# Altium schematic sheet 设置按键.SchDoc
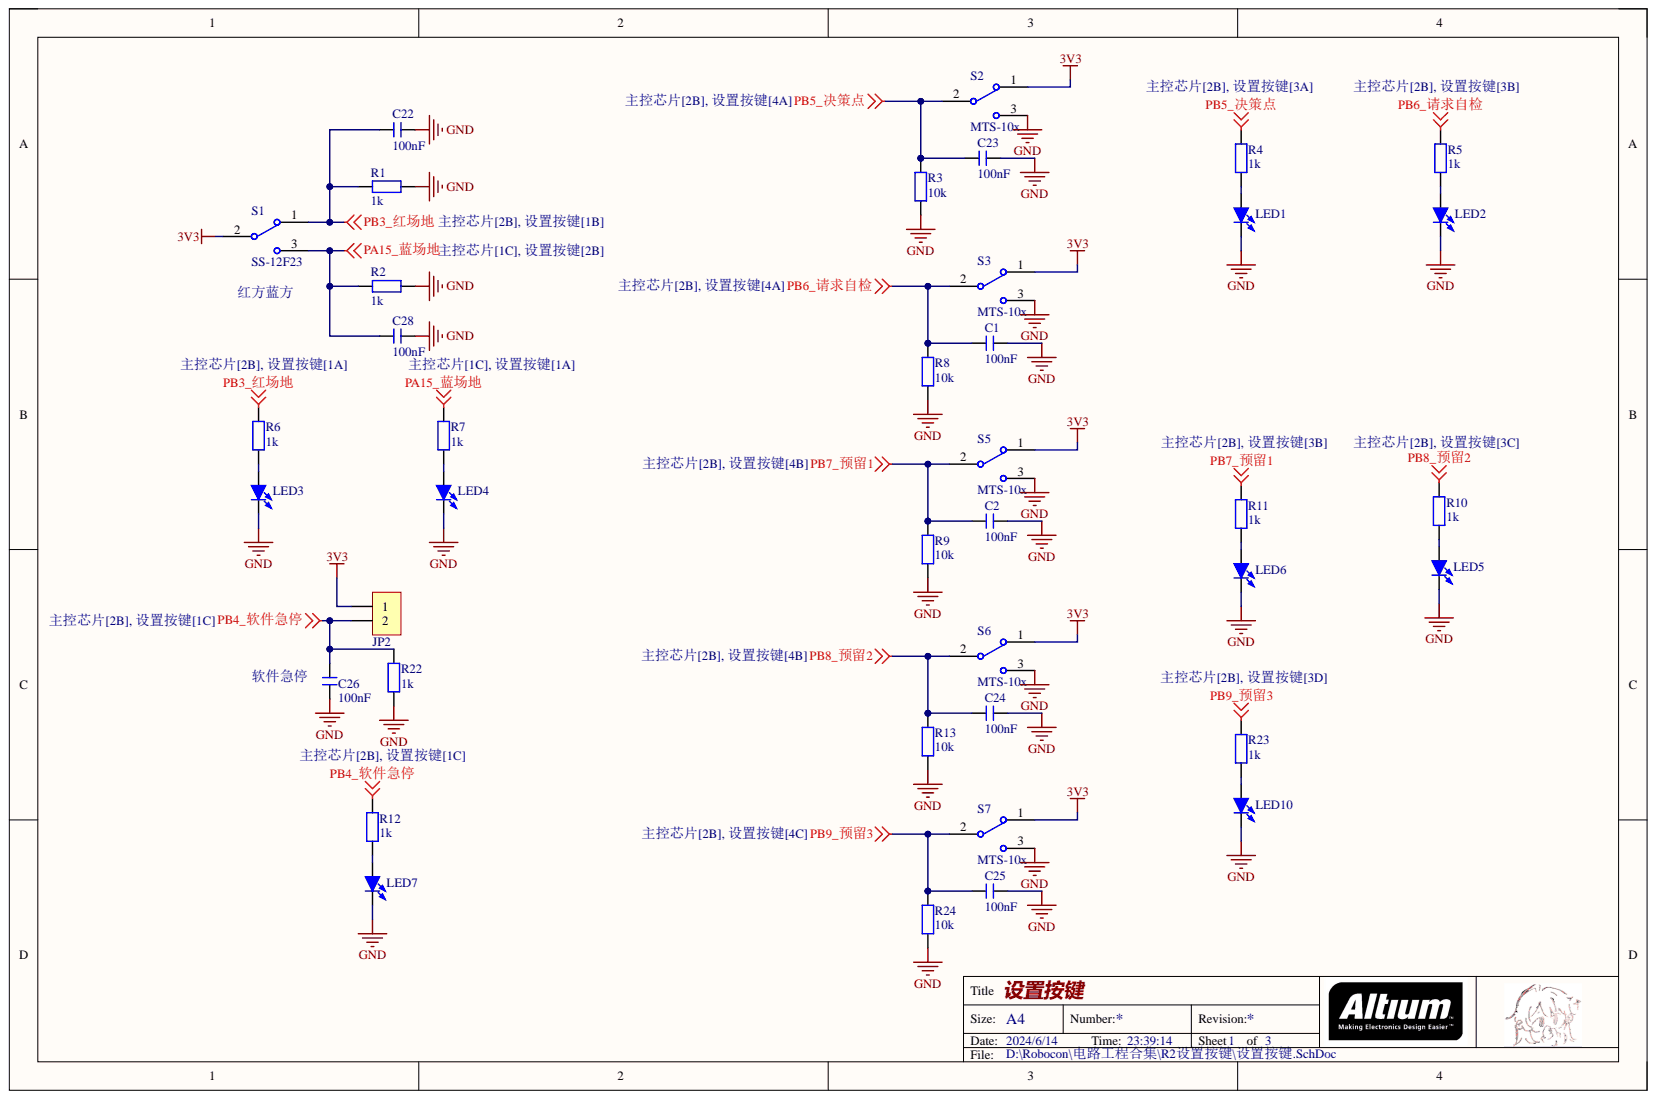
[diagram p0: 设置按键.SchDoc]

## Parts
C1  100nF  [C 0603_L]
C2  100nF  [C 0603_L]
C22  100nF  [C 0603_L]
C23  100nF  [C 0603_L]
C24  100nF  [C 0603_L]
C25  100nF  [C 0603_L]
C26  100nF  [C 0603_L]
C28  100nF  [C 0603_L]
JP2  HDR-1X2  [15EDGRM-02P-3.81mm]  (value not drawn)
LED1  红色  [LED 0603W]  (value not drawn)
LED2  红色  [LED 0603W]  (value not drawn)
LED3  红色  [LED 0603R]  (value not drawn)
LED4  红色  [LED 0603B]  (value not drawn)
LED5  红色  [LED 0603W]  (value not drawn)
LED6  红色  [LED 0603W]  (value not drawn)
LED7  红色  [LED 0603W]  (value not drawn)
LED10  红色  [LED 0603W]  (value not drawn)
R1  1k  [R 0603_L]
R2  1k  [R 0603_L]
R3  10k  [R 0603_L]
R4  1k  [R 0603_L]
R5  1k  [R 0603_L]
R6  1k  [R 0603_L]
R7  1k  [R 0603_L]
R8  10k  [R 0603_L]
R9  10k  [R 0603_L]
R10  1k  [R 0603_L]
R11  1k  [R 0603_L]
R12  1k  [R 0603_L]
R13  10k  [R 0603_L]
R22  1k  [R 0603_L]
R23  1k  [R 0603_L]
R24  10k  [R 0603_L]
S1  SS-12F23  [SS-12F23]
S2  MTS-10x  [MTS-10x]
S3  MTS-10x  [MTS-10x]
S5  MTS-10x  [MTS-10x]
S6  MTS-10x  [MTS-10x]
S7  MTS-10x  [MTS-10x]

## Nets
3V3: JP2.1 S1.2 S2.1 S3.1 S5.1 S6.1 S7.1
GND: C1.1 C2.1 C22.1 C23.1 C24.1 C25.1 C26.2 C28.1 LED1.2 LED2.2 LED3.2 LED4.2 LED5.2 LED6.2 LED7.2 LED10.2 R1.1 R2.1 R3.1 R8.1 R9.1 R13.1 R22.1 R24.1 S2.3 S3.3 S5.3 S6.3 S7.3
PA15_蓝场地: C28.2 R2.2 R7.2 S1.3
PB3_红场地: C22.2 R1.2 R6.2 S1.1
PB4_软件急停: C26.1 JP2.2 R12.2 R22.2
PB5_决策点: C23.2 R3.2 R4.2 S2.2
PB6_请求自检: C1.2 R5.2 R8.2 S3.2
PB7_预留1: C2.2 R9.2 R11.2 S5.2
PB8_预留2: C24.2 R10.2 R13.2 S6.2
PB9_预留3: C25.2 R23.2 R24.2 S7.2
NetLED1_1: LED1.1 R4.1
NetLED2_1: LED2.1 R5.1
NetLED3_1: LED3.1 R6.1
NetLED4_1: LED4.1 R7.1
NetLED5_1: LED5.1 R10.1
NetLED6_1: LED6.1 R11.1
NetLED7_1: LED7.1 R12.1
NetLED10_1: LED10.1 R23.1

## Library data of the parts
C1 C2 C22-C26 C28 = C: 贴片电容; BOM名称: 无极性贴片电容; 淘宝ID: 封装库来自淘宝 ID：黄晶宝石; Component Kind: Standard; Library Name: 0.1 - 电阻-电容-电感.SchLib; 唯一出售店铺网址: https://shop463601444.taobao.com; 联系售后，技术支持: https://shop463601444.taobao.com
JP2 = Header 2: 2P接插件; 技术支持，联系售后: 封装库来自淘宝 ID：黄晶宝石; BOM名称: 2P接插件; Component Kind: Standard; Library Name: 5.6 - 接插件-脚距3.81 (150mil) .SchLib; 版权归属: https://shop463601444.taobao.com
LED1-LED7 LED10 = LED-SMD: 贴片LED; 版权归属: 封装库来自淘宝 ID：黄晶宝石; BOM名称: 贴片LED; Component Kind: Standard; Library Name: 0.3 - LED发光二极管.SchLib; 学习资源: https://shop463601444.taobao.com
R1-R13 R22-R24 = R: 贴片电阻; 封装库来自淘宝卖家 ID：黄晶宝石: 其他店铺购入均为盗版，不享受售后技术支持服务，请立即申请退款; 版权归属: 来自淘宝 ID：黄晶宝石; BOM名称: 贴片电阻; Component Kind: Standard; Library Name: 0.1 - 电阻-电容-电感.SchLib; 联系售后: https://shop463601444.taobao.com
S1 = SSW-1P: 1路波动开关; BOM名称: 波动开关; 版权归属: 封装库来自淘宝 ID：黄晶宝石; Component Kind: Standard; Library Name: 0.7 - 常用按键-开关.SchLib; 学习资源: https://shop463601444.taobao.com
S2 S3 S5-S7 = MTS-10x: MTS10x系列3脚扭子开关; 版权归属: 封装库来自淘宝 ID：黄晶宝石; BOM名称: MTS10x系列3脚扭子开关; Component Kind: Standard; Library Name: 0.7 - 常用按键-开关.SchLib; 学习资源: https://shop463601444.taobao.com
